# Altium schematic sheet Main.SchDoc
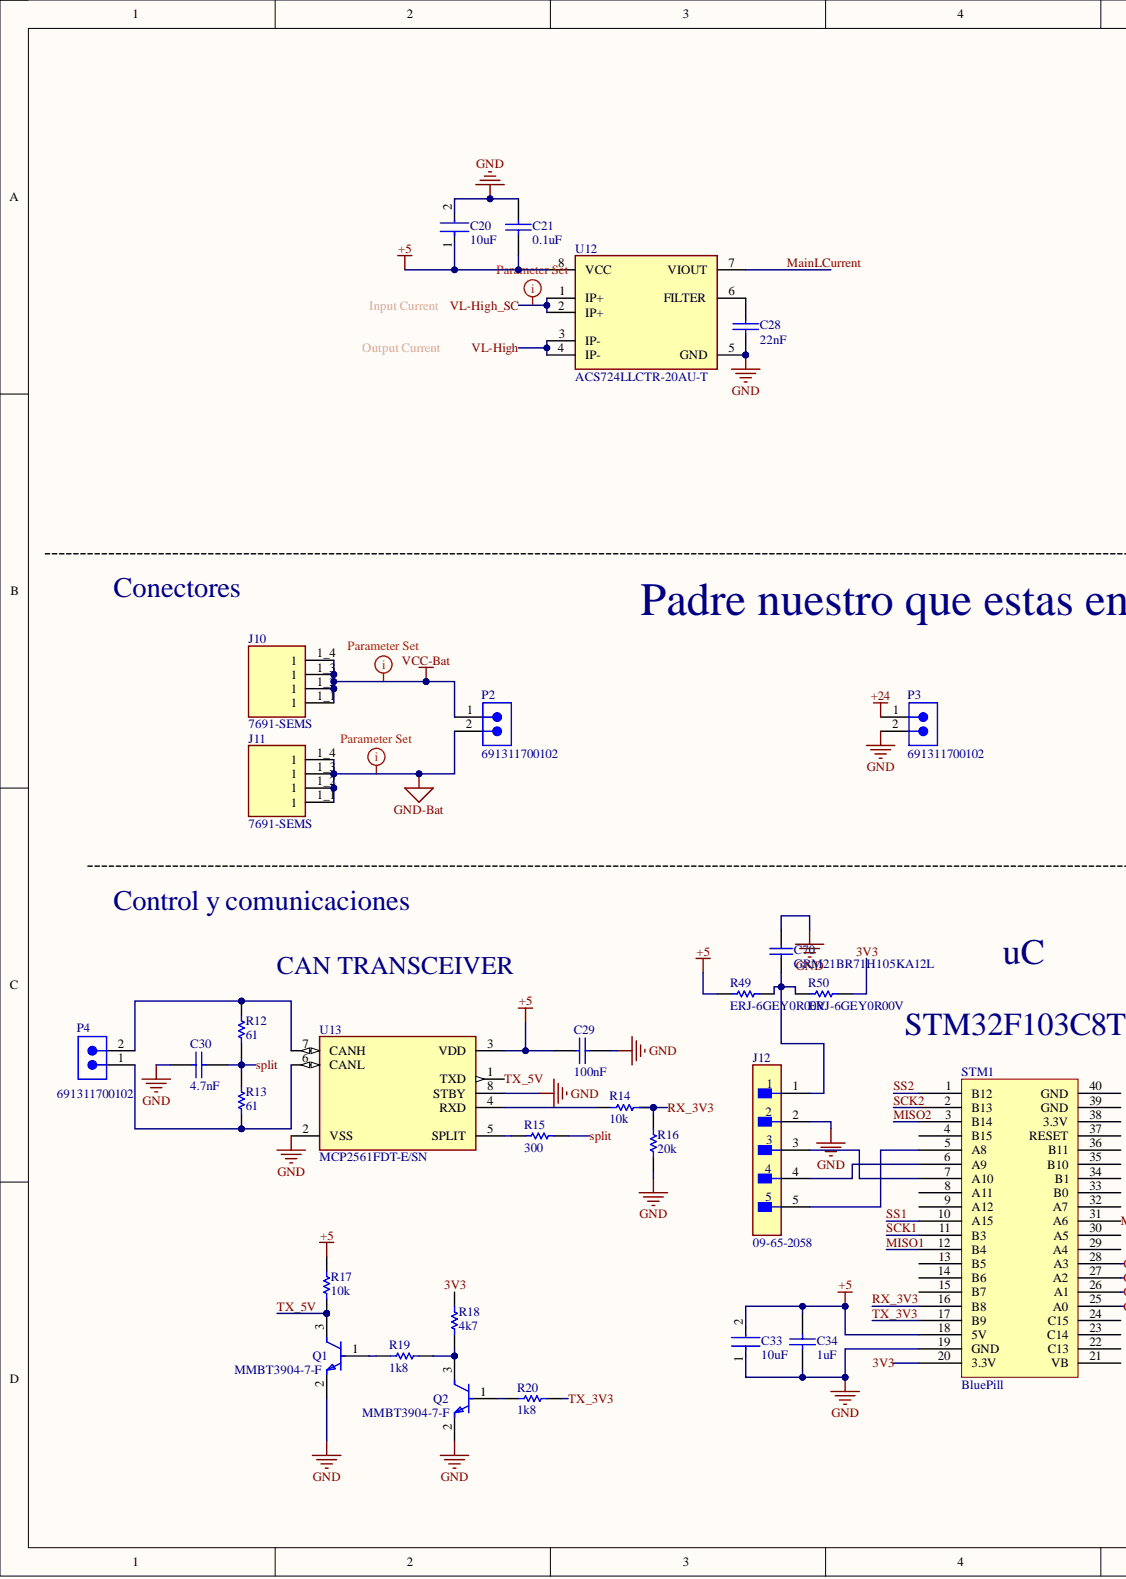
[diagram p0: Main.SchDoc, left]
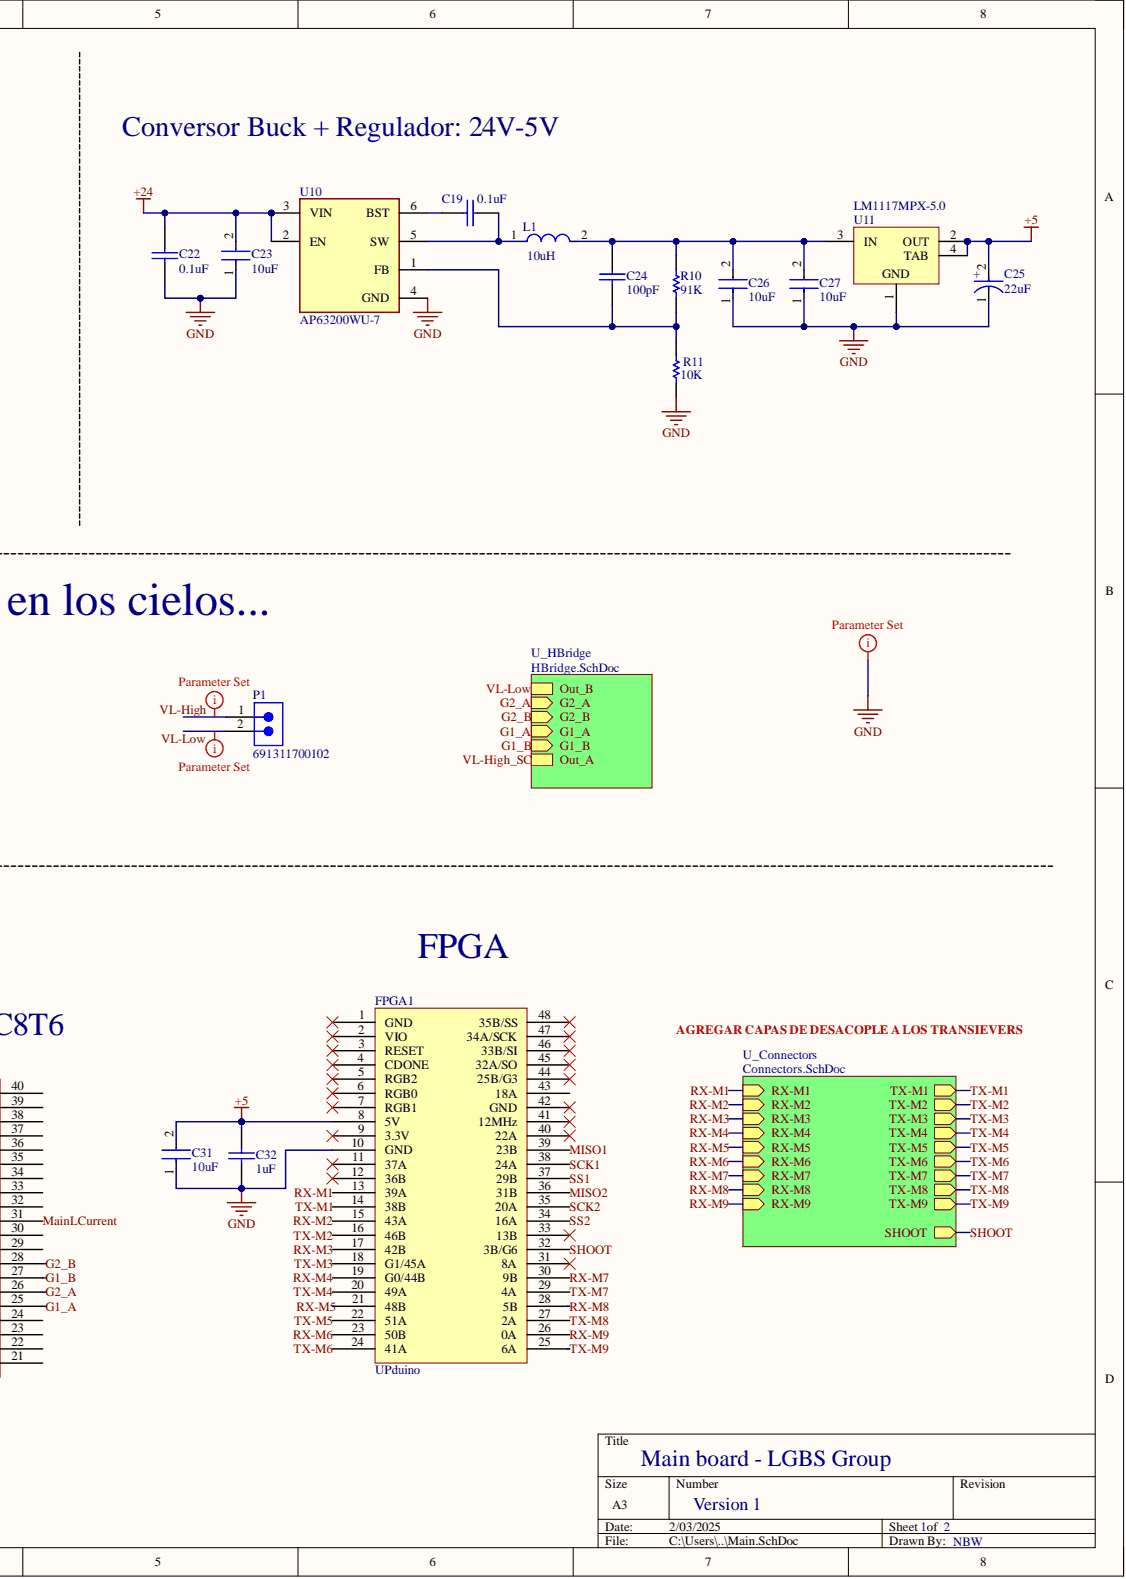
[diagram p1: Main.SchDoc, right]

## Parts
C19  0.1uF  [0805]
C20  10uF  [FP-CL31B106KBHNNNE-IPC_A]
C21  0.1uF  [0805]
C22  0.1uF  [0805]
C23  10uF  [FP-CL31B106KBHNNNE-IPC_A]
C24  100pF  [0805]
C25  22uF  [FP-293DC-MFG]
C26  10uF  [FP-CL31B106KBHNNNE-IPC_A]
C27  10uF  [FP-CL31B106KBHNNNE-IPC_A]
C28  22nF  [0805]
C29  100nF  [0805]
C30  4.7nF  [0805]
C31  10uF  [FP-CL31B106KBHNNNE-IPC_A]
C32  1uF  [0805]
C33  10uF  [FP-CL31B106KBHNNNE-IPC_A]
C34  1uF  [0805]
C70  GRM21BR71H105KA12L  [CAPC2013X135X45ML10T25]
FPGA1  UPduino  [FPGA]
J10  7691-SEMS  [KEYSTONE_7691-SEMS]
J11  7691-SEMS  [KEYSTONE_7691-SEMS]
J12  09-65-2058  [FP-09-65-2058-MFG]
L1  10uH  [FP-NR6045-MFG]
P1  691311700102  [691311700102]
P2  691311700102  [691311700102]
P3  691311700102  [691311700102]
P4  691311700102  [691311700102]
Q1  MMBT3904-7-F  [FP-SOT23-IPC_A]
Q2  MMBT3904-7-F  [FP-SOT23-IPC_A]
R10  91K  [0805]
R11  10K  [0805]
R12  61  [0805]
R13  61  [0805]
R14  10k  [0805]
R15  300  [0805]
R16  20k  [0805]
R17  10k  [0805]
R18  4k7  [0805]
R19  1k8  [0805]
R20  1k8  [0805]
R49  ERJ-6GEY0R00V  [RESC2013X70X40ML20T20]
R50  ERJ-6GEY0R00V  [RESC2013X70X40ML20T20]
STM1  BluePill  [BLACKPILL]
U10  AP63200WU-7  [FP-TSOT26-MFG]
U11  LM1117MPX-5.0  [DCY0004A_L]
U12  ACS724LLCTR-20AU-T  [FP-LCN-8-IPC_C]
U13  MCP2561FDT-E/SN  [FP-C04-057-SN-MFG]

## Nets
+5: C20.1 C21.2 C25.2 C29.1 C31.2 C32.1 C33.2 C34.1 FPGA1.8(5V) R17.2 R49.1 STM1.18(5V) U11.2(OUT) U11.4(TAB) U12.8(VCC) U13.3(VDD)
+24: C22.1 C23.2 P3.1 U10.2(EN) U10.3(VIN)
GND: C20.2 C21.1 C22.2 C23.1 C25.1 C26.1 C27.1 C28.2 C29.2 C30.2 C31.1 C32.2 C33.1 C34.2 C70.2 FPGA1.10(GND) J12.2 P3.2 Q1.2 Q2.2 R11.2 R16.2 STM1.19(GND) U10.4(GND) U11.1 U12.5(GND) U13.2(VSS) U13.8(STBY)
GND-Bat: J11.1_1 J11.1_2 J11.1_3 J11.1_4 P2.2
VCC-Bat: J10.1_1 J10.1_2 J10.1_3 J10.1_4 P2.1
3V3: R18.2 R50.2 STM1.20(3.3V)
G1_A: STM1.25(A0)
G1_B: STM1.27(A2)
G2_A: STM1.26(A1)
G2_B: STM1.28(A3)
MainLCurrent: STM1.31(A6) U12.7(VIOUT)
MISO1: FPGA1.39(23B) STM1.12(B4)
MISO2: FPGA1.36(31B) STM1.3(B14)
RX-M1: FPGA1.13(39A)
RX-M2: FPGA1.15(43A)
RX-M3: FPGA1.17(42B)
RX-M4: FPGA1.19(G0/44B)
RX-M5: FPGA1.21(48B)
RX-M6: FPGA1.23(50B)
RX-M7: FPGA1.30(9B)
RX-M8: FPGA1.28(5B)
RX-M9: FPGA1.26(0A)
RX_3V3: R14.2 R16.1 STM1.16(B8)
SCK1: FPGA1.38(24A) STM1.11(B3)
SCK2: FPGA1.35(20A) STM1.2(B13)
SHOOT: FPGA1.32(3B/G6)
split: C30.1 R12.1 R13.2 R15.1
SS1: FPGA1.37(29B) STM1.10(A15)
SS2: FPGA1.34(16A) STM1.1(B12)
TX-M1: FPGA1.14(38B)
TX-M2: FPGA1.16(46B)
TX-M3: FPGA1.18(G1/45A)
TX-M4: FPGA1.20(49A)
TX-M5: FPGA1.22(51A)
TX-M6: FPGA1.24(41A)
TX-M7: FPGA1.29(4A)
TX-M8: FPGA1.27(2A)
TX-M9: FPGA1.25(6A)
TX_3V3: R20.2 STM1.17(B9)
TX_5V: Q1.3 R17.1 U13.1(TXD)
VL-High: P1.1 U12.3(IP-) U12.4(IP-)
VL-High_SC: U12.1(IP+) U12.2(IP+)
VL-Low: P1.2
NetC19_1: C19.1 U10.6(BST)
NetC19_2: C19.2 L1.1 U10.5(SW)
NetC24_1: C24.1 C26.2 C27.2 L1.2 R10.1 U11.3(IN)
NetC24_2: C24.2 R10.2 R11.1 U10.1(FB)
NetC28_1: C28.1 U12.6(FILTER)
NetC70_1: C70.1 J12.1 R49.2 R50.1
NetFPGA1_1: FPGA1.1(GND)
NetFPGA1_2: FPGA1.2(VIO)
NetFPGA1_3: FPGA1.3(RESET)
NetFPGA1_4: FPGA1.4(CDONE)
NetFPGA1_5: FPGA1.5(RGB2)
NetFPGA1_6: FPGA1.6(RGB0)
NetFPGA1_7: FPGA1.7(RGB1)
NetFPGA1_9: FPGA1.9(3.3V)
NetFPGA1_11: FPGA1.11(37A)
NetFPGA1_12: FPGA1.12(36B)
NetFPGA1_31: FPGA1.31(8A)
NetFPGA1_33: FPGA1.33(13B)
NetFPGA1_40: FPGA1.40(22A)
NetFPGA1_41: FPGA1.41(12MHz)
NetFPGA1_42: FPGA1.42(GND)
NetFPGA1_43: FPGA1.43(18A)
NetFPGA1_44: FPGA1.44(25B/G3)
NetFPGA1_45: FPGA1.45(32A/SO)
NetFPGA1_46: FPGA1.46(33B/SI)
NetFPGA1_47: FPGA1.47(34A/SCK)
NetFPGA1_48: FPGA1.48(35B/SS)
NetJ12_3: J12.3 STM1.7(A10)
NetJ12_4: J12.4 STM1.6(A9)
NetJ12_5: J12.5 STM1.5(A8)
NetP4_1: P4.1 R13.1 U13.6(CANL)
NetP4_2: P4.2 R12.2 U13.7(CANH)
NetQ1_1: Q1.1 R19.2
NetQ2_1: Q2.1 R20.1
NetQ2_3: Q2.3 R18.1 R19.1
NetR14_1: R14.1 U13.4(RXD)
NetR15_2: R15.2 U13.5(SPLIT)
NetSTM1_4: STM1.4(B15)
NetSTM1_8: STM1.8(A11)
NetSTM1_9: STM1.9(A12)
NetSTM1_13: STM1.13(B5)
NetSTM1_14: STM1.14(B6)
NetSTM1_15: STM1.15(B7)
NetSTM1_21: STM1.21(VB)
NetSTM1_22: STM1.22(C13)
NetSTM1_23: STM1.23(C14)
NetSTM1_24: STM1.24(C15)
NetSTM1_29: STM1.29(A4)
NetSTM1_30: STM1.30(A5)
NetSTM1_32: STM1.32(A7)
NetSTM1_33: STM1.33(B0)
NetSTM1_34: STM1.34(B1)
NetSTM1_35: STM1.35(B10)
NetSTM1_36: STM1.36(B11)
NetSTM1_37: STM1.37(RESET)
NetSTM1_38: STM1.38(3.3V)
NetSTM1_39: STM1.39(GND)
NetSTM1_40: STM1.40(GND)

## Library data of the parts
C19 C21 C22 C24 C28-C30 C32 C34 = CAP: Component Kind: Standard; Library Name: LIBEBAL.IntLib
C20 C23 C26 C27 C31 C33 = CMP-2000-07530-2: CAP CER 10UF 50V X7R 1206 -CL31B106KBHNNNE; Manufacturer: Samsung; Material: Ceramic; Temperature Coefficient: 15%; Tolerance: 10%; Automotive: No; Capacitance: 10uF; Category: Cap; Component Kind: Standard; ComponentLink2Description: Datasheet; ComponentLink2URL: http://www.samsungsem.com/global/front/downloadcms.do?path=%2F%2Fglobal%2F%2Fsupport%2F%2Fproduct-search%2F%2Fmlcc%2F%2F__icsFiles%2F%2Fafieldfile%2F%2F2019%2F%2F06%2F%2F18&fileName=CL31B106KBHNNNE-19.pdf; Device Class L1: Passive Components; Device Class L2: Capacitors; Device Class L3: Ceramic Capacitors; Digikey Description: CAP CER 10UF 50V X7R 1206; Height: 1.8mm; Lead Free: Yes; Library Name: Altium Content Vault; Manufacturer Part Number: CL31B106KBHNNNE; Octopart: https://octopart.com/cl31b106kbhnnne-samsung-30507377; Package: CL31B106KBHNNNE; RoHS: TRUE; Temperature: -55°C to +125°C; Temperature Characteristic: X7R; Temperature Range High: +125°C; Temperature Range Low: -55°C; Value: 10uF; Voltage Rating: 50V
C25 = CMP-02414-000014-1: CAP TANT 22UF 10% 16V 2312 - 293D226X9016C2TE3; Dielectric Material: Tantalum; Dissipation Factor: 6%; ESR (Equivalent Series Resistance): 1.4R; Leakage Current: 3.5uA; Polarity: Polar; Q Factor: 6; Ripple Current: 210mA; Capacitance: 22uF; Case Code (Imperial): 2312; Case Code (Metric): 6032; Case/Package: Molded; Component Kind: Standard; Depth: 3.2mm; Features: General Purpose; Height: 2.5mm; Height - Seated (Max): 0.11inch; Lead Free: Lead Free; Length: 6mm; Library Name: Altium Content Vault; Max Operating Temperature: 125°C; Min Operating Temperature: -55°C; Mount: Surface Mount; Package Quantity: 1; Packaging: Tape and Reel; Radiation Hardening: No; RoHS Compliant: Yes; Series: TANTAMOUNT® 293D; Termination: SMD/SMT; Tolerance: 10%; Voltage: 16V; Voltage Rating: 16V; Voltage Rating (DC): 16V; Width: 0.126inch
C70 = CMP-1036-04761-2: Manufacturer: Murata; Component Kind: Standard; Library Name: Altium Content Vault; Part Number: GRM21BR71H105KA12L
FPGA1 = FPGA: upduino v3.0; Component Kind: Standard; Library Name: MCSI.SchLib
J10 J11 = CMP-012-000000-1: Availability: Unavailable; Component Kind: Standard; Component Type: Standard; Design Item ID: 7691-SEMS; MP: 7691-SEMS; Package: None; Pin Count: 4; Price: None; Source: 7691-SEMS.SchLib; Library Name: ITBA-LGBS
J12 = CMP-00000-1: Board Connector, 5 Contact(s), 1 Row(s), Male, Straight, Solder Terminal, Locking, Natural Insulator, Receptacle; Body Breadth: 0.402inch; Body Depth: 0.482inch; Body Length: 0.75inch; Contact Resistance: 10mR; Contact Style: SQ PIN-SKT; Dielectric Withstanding Voltage (AC): 1.5kV; DIN Conformance: No; Durability: 30Cycles; Insulation Resistance: 1GR; Mating Information: MULTIPLE MATING PARTS AVAILABLE; Number Of Connectors: 1; Number of Contacts: 5; Option: General Purpose; Reference Standard: UL; Reliability: COMMERCIAL; Throw Configuration: S0P5; Body/Shell Style: RECEPTACLE; Component Kind: Standard; Connector Type: BOARD CONNECTOR; Contact Finish - Mating: SN ON CU; Contact Finish - Termination: Tin/Copper (Sn/Cu); Contact Gender: Male; Contact Material: Brass; Filter Feature: No; Flammability Rating: UL94 V-2; IEC Conformance: No; Insulation Color: Natural; Insulation Material: Nylon; JESD-609 Code: e2; Max Operating Temperature: 105°C; MIL Conformance: No; Min Operating Temperature: -40°C; Mixed Contacts: No; Mounting Option 1: LOCKING; Mounting Style: STRAIGHT; Mounting Technology: Board; Number of Rows Loaded: 1; Reach SVHC Compliant: Yes; RoHS Compliant: Yes; Terminal Length: 0.142inch; Terminal Pitch: 3.96mm; Termination: Solder; Library Name: ITBA-LGBS
L1 = CMP-14484-001321-1: Shielded Wirewound Inductor 10uH 20% 2.8A 59.8mΩ SMD - NRS6045T100MMGK; Core Material: Ferrite; Current Rating: 2.4A; DC Resistance (DCR): 59.8mR; Max DC Current: 3.1A; Self Resonant Frequency: 12MHz; Test Frequency: 100kHz; Case/Package: Nonstandard; Component Kind: Standard; Depth: 6.2mm; Halogen Free: Halogen Free; Height: 4.5mm; Height - Seated (Max): 0.177inch; Inductance: 10uH; Inductance Tolerance: 20%; Lead Free: Lead Free; Lead Pitch: 4.7mm; Length: 6mm; Library Name: Altium Content Vault; Max Operating Temperature: 125°C; Min Operating Temperature: -25°C; Mount: Surface Mount; Number of Pins: 2; Package Quantity: 1500; Packaging: Tape and Reel; Radiation Hardening: No; Resistor Type: Wirewound; RMS Current (Irms): 2.4A; RoHS Compliant: Yes; Saturation Current: 3.1A; Series: NRS; Shielding: Shielded; Termination: SMD/SMT; Tolerance: 20%; Width: 0.236inch
P1 = CMP-1502-02033-2: Main Inductor CONNECTOR; NexarPartId: 18818310; Current: 20A; Gender: Male; Number of Contacts: 2; Number of Levels: 1; Pitch: 5.0038mm; Voltage: 300V; Color: Green; Component Kind: Standard; Contact Material: Brass; Height: 12mm; Lead Pitch: 5mm; Length: 8.5mm; Library Name: Altium Content Vault; Mount: Through Hole; Number of Pins: 2; Number of Positions Per Level: 2; Number of Rows: 1; Orientation: Vertical; Packaging: Box; REACH SVHC: Unknown; RoHS: Compliant; Termination: Solder; Width: 12mm
P2 = CMP-1502-02033-2: BATTERY CONNECTOR; NexarPartId: 18818310; Current: 20A; Gender: Male; Number of Contacts: 2; Number of Levels: 1; Pitch: 5.0038mm; Voltage: 300V; Color: Green; Component Kind: Standard; Contact Material: Brass; Height: 12mm; Lead Pitch: 5mm; Length: 8.5mm; Library Name: Altium Content Vault; Mount: Through Hole; Number of Pins: 2; Number of Positions Per Level: 2; Number of Rows: 1; Orientation: Vertical; Packaging: Box; REACH SVHC: Unknown; RoHS: Compliant; Termination: Solder; Width: 12mm
P3 = CMP-1502-02033-2: 24V DC CONNECTOR; NexarPartId: 18818310; Current: 20A; Gender: Male; Number of Contacts: 2; Number of Levels: 1; Pitch: 5.0038mm; Voltage: 300V; Color: Green; Component Kind: Standard; Contact Material: Brass; Height: 12mm; Lead Pitch: 5mm; Length: 8.5mm; Library Name: Altium Content Vault; Mount: Through Hole; Number of Pins: 2; Number of Positions Per Level: 2; Number of Rows: 1; Orientation: Vertical; Packaging: Box; REACH SVHC: Unknown; RoHS: Compliant; Termination: Solder; Width: 12mm
P4 = CMP-1502-02033-2: CAN CONNECTOR; NexarPartId: 18818310; Current: 20A; Gender: Male; Number of Contacts: 2; Number of Levels: 1; Pitch: 5.0038mm; Voltage: 300V; Color: Green; Component Kind: Standard; Contact Material: Brass; Height: 12mm; Lead Pitch: 5mm; Length: 8.5mm; Library Name: Altium Content Vault; Mount: Through Hole; Number of Pins: 2; Number of Positions Per Level: 2; Number of Rows: 1; Orientation: Vertical; Packaging: Box; REACH SVHC: Unknown; RoHS: Compliant; Termination: Solder; Width: 12mm
Q1 Q2 = CMP-2000-04931-2: TRANS NPN 40V 0.2A SMD SOT23-3; Collector Base Voltage (VCBO): 60V; Collector Emitter Saturation Voltage: 300mV; Continuous Collector Current: 200mA; Element Configuration: Single; Emitter Base Voltage (VEBO): 6V; Frequency: 300MHz; hFE Min: 100; Max Power Dissipation: 300mW; Number of Elements: 1; Power Dissipation: 350mW; Case/Package: SOT-23; Collector Emitter Breakdown Voltage: 40V; Collector Emitter Voltage (VCEO): 40V; Component Kind: Standard; Contact Plating: Tin; Gain Bandwidth Product: 300MHz; Height: 1mm; Lead Free: Lead Free; Length: 3.05mm; Library Name: Altium Content Vault; Max Breakdown Voltage: 40V; Max Collector Current: 200mA; Max Frequency: 300MHz; Max Operating Temperature: 150°C; Min Operating Temperature: -55°C; Mount: Surface Mount; Number of Pins: 3; Package Quantity: 3000; Packaging: Tape and Reel; Polarity: NPN; Radiation Hardening: No; REACH SVHC: No SVHC; Transition Frequency: 300MHz; Voltage Rating (DC): 40V; Weight: 0.000282oz; Width: 1.4mm
R10-R20 = RES: Component Kind: Standard; Library Name: LIBEBAL.IntLib
R49 R50 = CMP-1665-00002-5: Chip Resistor, 0 Ohm, +/- 1%, 0.125 W, -55 to 155 degC, 0805 (2012 Metric), RoHS, Tape and Reel; Resistance: 0 Ohm; Tolerance: 5%; Case Code (Imperial): 0805; Case Code (Metric): 2012; Case/Package: 0805; Component Kind: Standard; ComponentLink1Description: Manufacturer URL; ComponentLink1URL: http://www.panasonic.com/; ComponentLink2Description: Datasheet; ComponentLink2URL: http://industrial.panasonic.com/cdbs/www-data/pdf/RDA0000/AOA0000C84.pdf; DatasheetVersion: 03/2015; Height: 0.6 mm; Length: 2 mm; Library Name: Altium Content Vault; Max Operating Temperature: 155 degC; Min Operating Temperature: -55 degC; Mounting Technology: Surface Mount; Package Quantity: 5000; PackageDescription: 2-Pin Surface Mount Device, Body 2 x 1.25 mm; Packaging: Tape and Reel; PartNumber: ERJ-6GEY0R00V; Radiation Hardening: No; REACH SVHC: No SVHC; RoHSCompliant: True; Width: 1.25 mm
STM1 = STM32: STM32F411 BLACKPILL EVAL BRD; Component Kind: Standard; Library Name: MCSI.SchLib
U10 = CMP-12757-000010-1: IC REG BUCK ADJUSTABLE 2A TSOT26; Manufacturer: Diodes Inc.; Max Junction Temp: +160°C; Max Supply Voltage: 32V; Min Supply Voltage: 3.8V; Nominal Supply Current: 22uA; Number Of Outputs: 1; Output Type: AdjustableProgrammable; Standoff Height: 0.01mm; Switching Frequency: 500kHz; Ambient Temperature Range High: +85°C; Ambient Temperature Range Low: -40°C; Automotive: No; Category: IC; Component Kind: Standard; ComponentLink2Description: Datasheet; ComponentLink2URL: https://www.diodes.com/assets/Datasheets/AP63200-AP63201-AP63203-AP63205.pdf; Device Class L1: Integrated Circuits (ICs); Device Class L2: Power Management ICs; Device Class L3: Voltage Regulators - Switching; Digikey Description: IC REG BUCK ADJUSTABLE 2A TSOT26; Footprint Url: https://www.diodes.com/assets/Package-Files/TSOT26.pdf; Height: 1mm; Ipc Land Pattern Name: SOT95P280X100-6; Lead Free: Yes; Library Name: Altium Content Vault; Manufacturer Part Number: AP63200WU-7; Mouser Description: AP63200 Series 1.2 V 2 A Adjustable Synchronous Buck Converter - TSOT-26; Octopart: https://octopart.com/ap63200wu-7-diodes+inc.-98099548; Output Current: 2A; Package: TSOT26; RoHS: TRUE; Switching Topology: Buck; Temperature: -40°C to +85°C; Temperature Range High: +85°C; Temperature Range Low: -40°C
U11 = CMP-0062-01637-4: 800mA Low-Dropout Linear Regulator, 4-pin SOT-223; Manufacturer: Texas Instruments; CompType: LDO IC; High-Reliability: Y; PackageTopMarking: XYTT*N06A; PowerWise: N; Code_IPC: SOT223-4; Code_JEDEC: TO-261-AA; Component Kind: Standard; ComponentLink1Description: Datasheet URL; ComponentLink1URL: http://www.ti.com/general/docs/lit/getliterature.tsp?genericPartNumber=LM1117-N&fileType=pdf; Library Name: Altium Content Vault; PackageDescription: Molded SOT-223, 6.50 x 3.56 x 1.6mm Body, 4 Lead, 2.3mm Pitch; PackageReference: DCY0004A; PartNumber: LM1117MPX-5.0; PinCount: 4; RoHS: N
U12 = CMP-82923-000080-2: SENSOR CURRENT HALL 20A DC; Accuracy: 0.7%; Frequency: 120kHz; Linearity: 1.5%; Max Supply Current: 14mA; Number of Channels: 1; Polarity: Unidirectional; Response Time: 4us; Sensitivity: 200mV/A; Case/Package: SOIC; Component Kind: Standard; Current Sensing: 20A; Library Name: Altium Content Vault; Max Operating Temperature: 150°C; Min Operating Temperature: -40°C; Mount: Surface Mount; Number of Pins: 8; Packaging: Tape and Reel; RoHS Compliant: Yes
U13 = CMP-06279-000064-1: IC TRANSCEIVER 1/1 8SOIC; Data Rate: 8Mbps; Max Supply Voltage: 5.5V; Min Supply Voltage: 4.5V; Number of Transceivers: 1; Operating Supply Current: 70mA; Receiver Hysteresis: 200mV; Case/Package: SOIC; Component Kind: Standard; Library Name: Altium Content Vault; Max Operating Temperature: 125°C; Min Operating Temperature: -40°C; Mount: Surface Mount; Number of Pins: 8; Package Quantity: 3300; Packaging: Tape and Reel; RoHS Compliant: Yes; Series: -; Weight: 0.019048oz
